# Altium schematic sheet Connectors.SchDoc(Connectors)
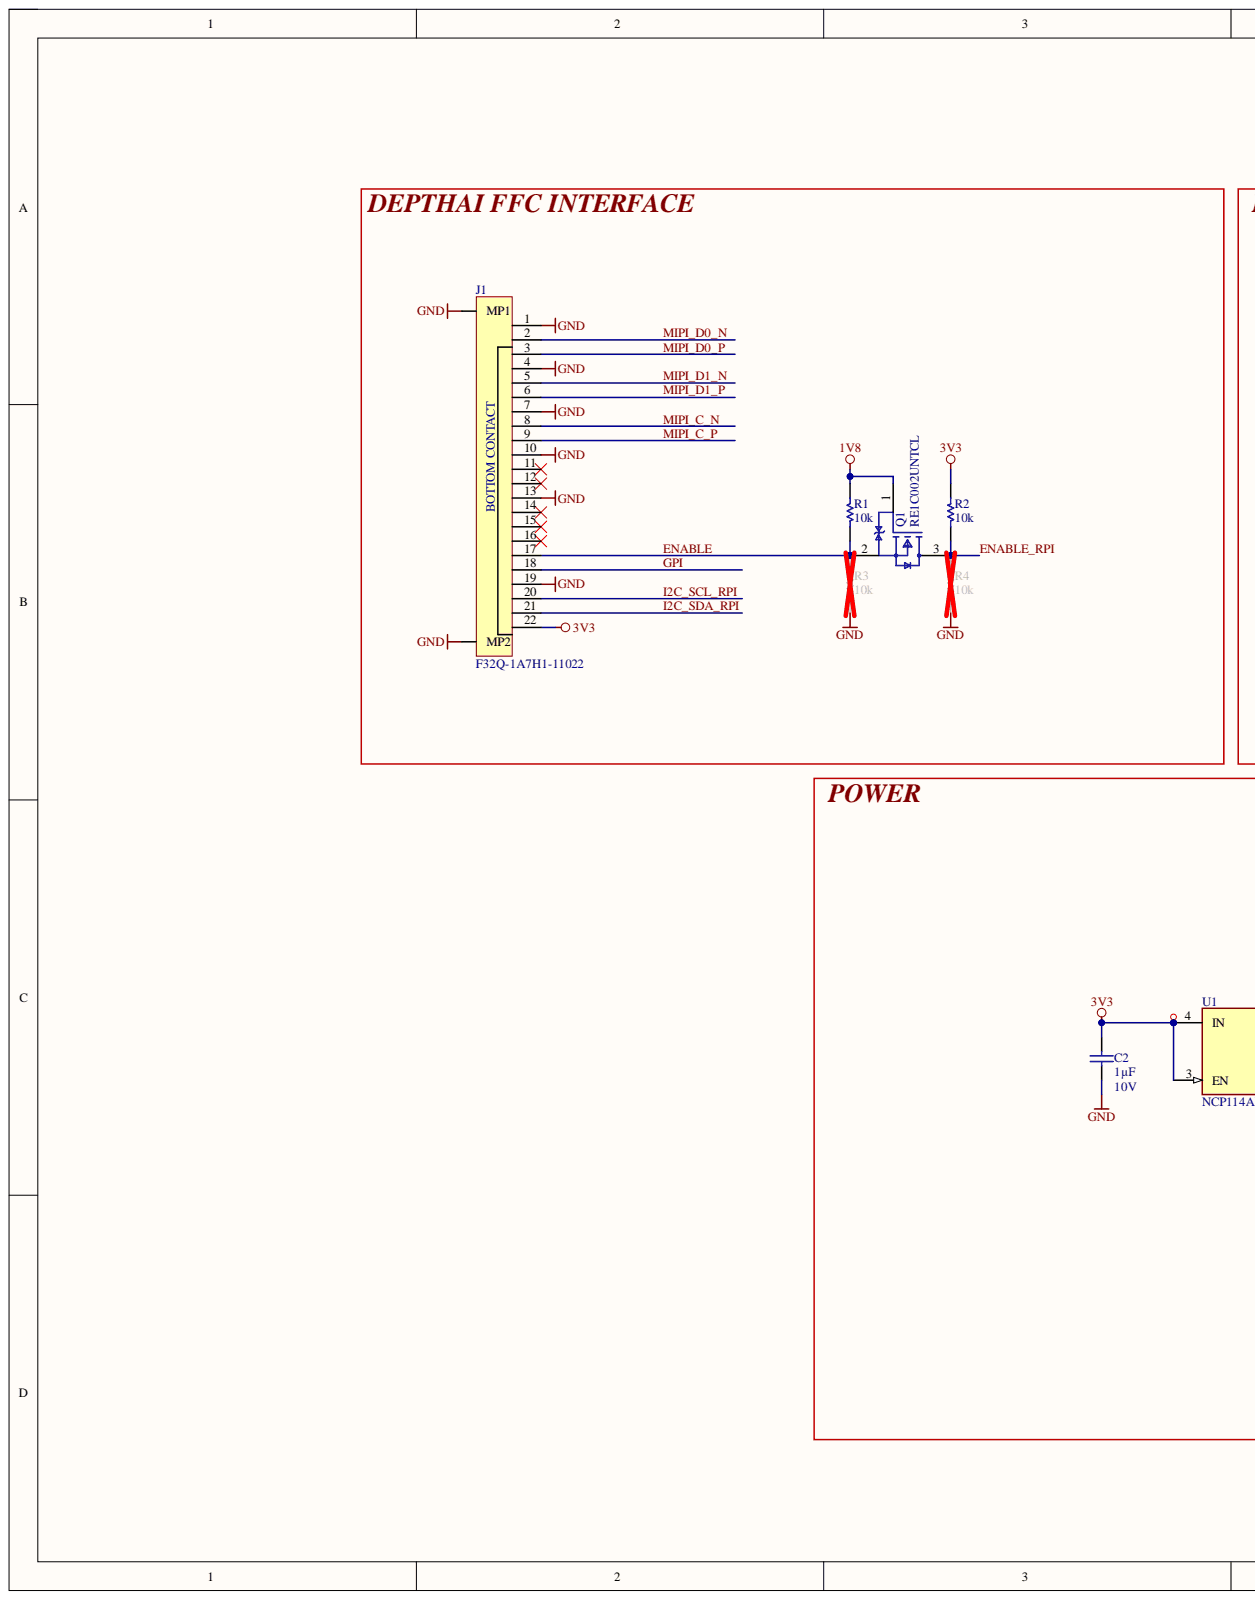
[diagram p0: Connectors.SchDoc, left]
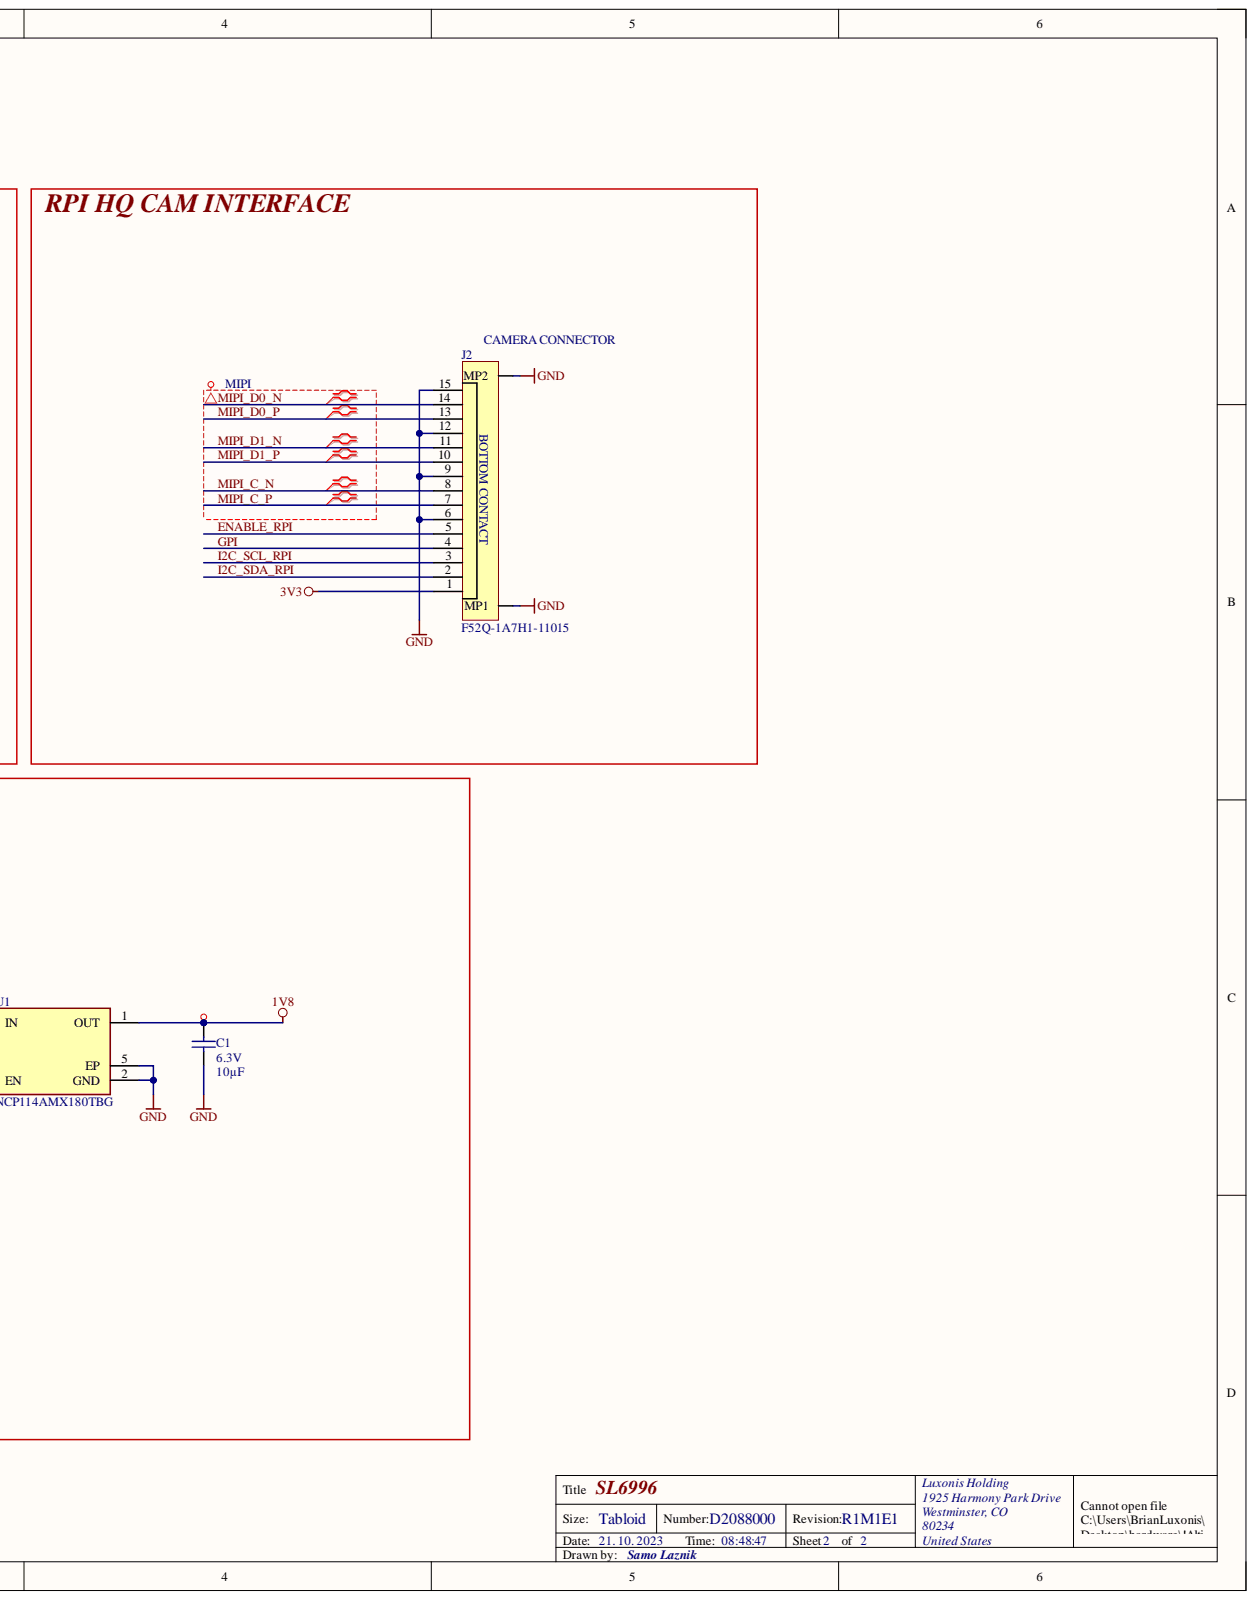
[diagram p1: Connectors.SchDoc, right]

## Parts
C1  value 10µF  Voltage Rating: 6.3V  [CAPC0402(1005)70_L]  (hidden comment: GRM155R60J106ME15D)
C2  value 1µF  Voltage Rating: 10V  [CAPC0201(0603)33_L]  (hidden comment: GRM033R61A105ME15D)
J1  F32Q-1A7H1-11022  [F32Q-1A7H1-11022]
J2  F52Q-1A7H1-11015  [F52Q-1A7H1-11015-Footprint-1]
Q1  RE1C002UNTCL  [RE1C002UNTCL]
R1  value 10k  [RESC0402(1005)_L]  (hidden comment: RC0402FR-1310KL)
R2  value 10k  [RESC0402(1005)_L]  (hidden comment: RC0402FR-1310KL)
R3  value 10k  [RESC0402(1005)_L]  (hidden comment: RC0402FR-1310KL)
R4  value 10k  [RESC0402(1005)_L]  (hidden comment: RC0402FR-1310KL)
U1  NCP114AMX180TBG  [FP-517CU-MFG]

## Nets
1V8: C1.2 Q1.1 R1.2 U1.1(OUT)
3V3: C2.2 J1.22 J2.1 R2.2 U1.3(EN) U1.4(IN)
GND: C1.1 C2.1 J1.1 J1.4 J1.7 J1.10 J1.13 J1.19 J1.MP1 J1.MP2 J2.6 J2.9 J2.12 J2.15 J2.MP1 J2.MP2 R3.1 R4.1 U1.2(GND) U1.5(EP)
ENABLE: J1.17 Q1.2 R1.1 R3.2
ENABLE_RPI: J2.5 Q1.3 R2.1 R4.2
GPI: J1.18 J2.4
I2C_SCL_RPI: J1.20 J2.3
I2C_SDA_RPI: J1.21 J2.2
MIPI_C_N: J1.8 J2.8
MIPI_C_P: J1.9 J2.7
MIPI_D0_N: J1.2 J2.14
MIPI_D0_P: J1.3 J2.13
MIPI_D1_N: J1.5 J2.11
MIPI_D1_P: J1.6 J2.10

Not connected: J1 11 12 14 15 16

## Library data of the parts
C1 = CMP-004-000009-5: CAP CER 10UF 6.3V X5R 0402 20%; Manufacturer 1: Murata; Case/Package: 0402; Component Kind: Standard; ComponentLink1Description: https://www.murata.com/~/media/webrenewal/support/library/catalog/products/capacitor/mlcc/c02e.ashx?la=en-us&src-supplier=Digi-Key; ComponentLink1URL: https://www.murata.com/~/media/webrenewal/support/library/catalog/products/capacitor/mlcc/c02e.ashx?la=en-us&src-supplier=Digi-Key; Design Item ID: GRM155R60J106ME15D; Dielectric: X5R; Length: 0.039inch; Manufacturer Part Number 1: GRM155R60J106ME15D; Max Operating Temperature: 85°C; Min Operating Temperature: -55°C; Mounting Technology: SMT; Tolerance: 20%; Width: 0.02inch; Library Name: Luxonis LLC
C2 = CMP-004-000026-6: CAP CER 1UF 10V X5R 0201 20%; Manufacturer 1: Murata; Capacitor Type: Ceramic; Case/Package: 0201; Component Kind: Standard; ComponentLink1Description: https://psearch.en.murata.com:443/capacitor/product/GRM033R61A105ME15%23.pdf?src-supplier=IHS+Markit; ComponentLink1URL: https://psearch.en.murata.com:443/capacitor/product/GRM033R61A105ME15%23.pdf?src-supplier=IHS+Markit; ComponentLink2Description: http://search.murata.co.jp/Ceramy/image/img/A01X/G101/ENG/GRM033R61A105ME15-01.pdf?src-supplier=Digi-Key; ComponentLink2URL: http://search.murata.co.jp/Ceramy/image/img/A01X/G101/ENG/GRM033R61A105ME15-01.pdf?src-supplier=Digi-Key; Design Item ID: GRM033R61A105ME15D; Dielectric: X5R; Manufacturer Part Number 1: GRM033R61A105ME15D; Max Operating Temperature: 85°C; Min Operating Temperature: -55°C; Mounting Technology: SMT; Tolerance: 20%; Library Name: Luxonis LLC
J1 = CMP-002-00195-1: FPC/FFC Connector Socket 22 Position 0.5mm Top Contact Solder Right Angle Surface Mount T/R; Manufacturer 1: Amphenol ICC / FCI; Pitch: 0.02inch; Voltage Rating: 50V; Component Kind: Standard; Manufacturer Part Number 1: F32Q-1A7H1-11022; Max Operating Temperature: 105°C; Min Operating Temperature: -40°C; Mount: Surface Mount; Pins: 22; System: connector; Library Name: Luxonis LLC
J2 = CMP-002-00189-2: FPC/FFC Connector Socket 15 Position 1mm Top Contact Solder Right Angle Surface Mount T/R; Manufacturer 1: Amphenol; Component Kind: Standard; Manufacturer Part Number 1: F52Q-1A7H1-11015; Pins: 15; System: connector; Library Name: Luxonis LLC
Q1 = CMP-120-000001-2: MOSFET N-CH 20V 0.2A EMT3; Manufacturer 1: Rohm; Continuous Drain Current: 200mA; Case/Package: SOT-89; Component Kind: Standard; Design Item ID: RE1C002UNTCL; Manufacturer Part Number 1: RE1C002UNTCL; Max Drain to Source Voltage: 20V; Library Name: Luxonis LLC
R1-R4 = CMP-115-000004-8: RES SMD 10K OHM 1% 1/16W 0402; Manufacturer 1: Yageo; Power Rating: 62.5mW; Temperature Coefficient: 100ppm/°C; Voltage Rating: 50V; Case/Package: 0402; Component Kind: Standard; Design Item ID: RC0402FR-1310KL; Manufacturer Part Number 1: RC0402FR-1310KL; Max Operating Temperature: 155°C; Min Operating Temperature: -55°C; Tolerance: 1%; Library Name: Luxonis LLC
U1 = CMP-00047-1: LDO Voltage Regulators 300 MA CMOS LDO AD O; Manufacturer 1: onsemi; Max Output Current: 300mA; Max Supply Voltage: 5.5V; Min Supply Voltage: 1.7V; Output Voltage: 1.8V; Case/Package: UDFN-4; Component Kind: Standard; Manufacturer Part Number 1: NCP114AMX180TBG; Max Operating Temperature: 85°C; Min Operating Temperature: -40°C; Pins: 5; Vfb/Vout: 1.8V; Library Name: Luxonis LLC
